# Altium schematic sheet Battery - Current_Probe_V0.2.SchDoc
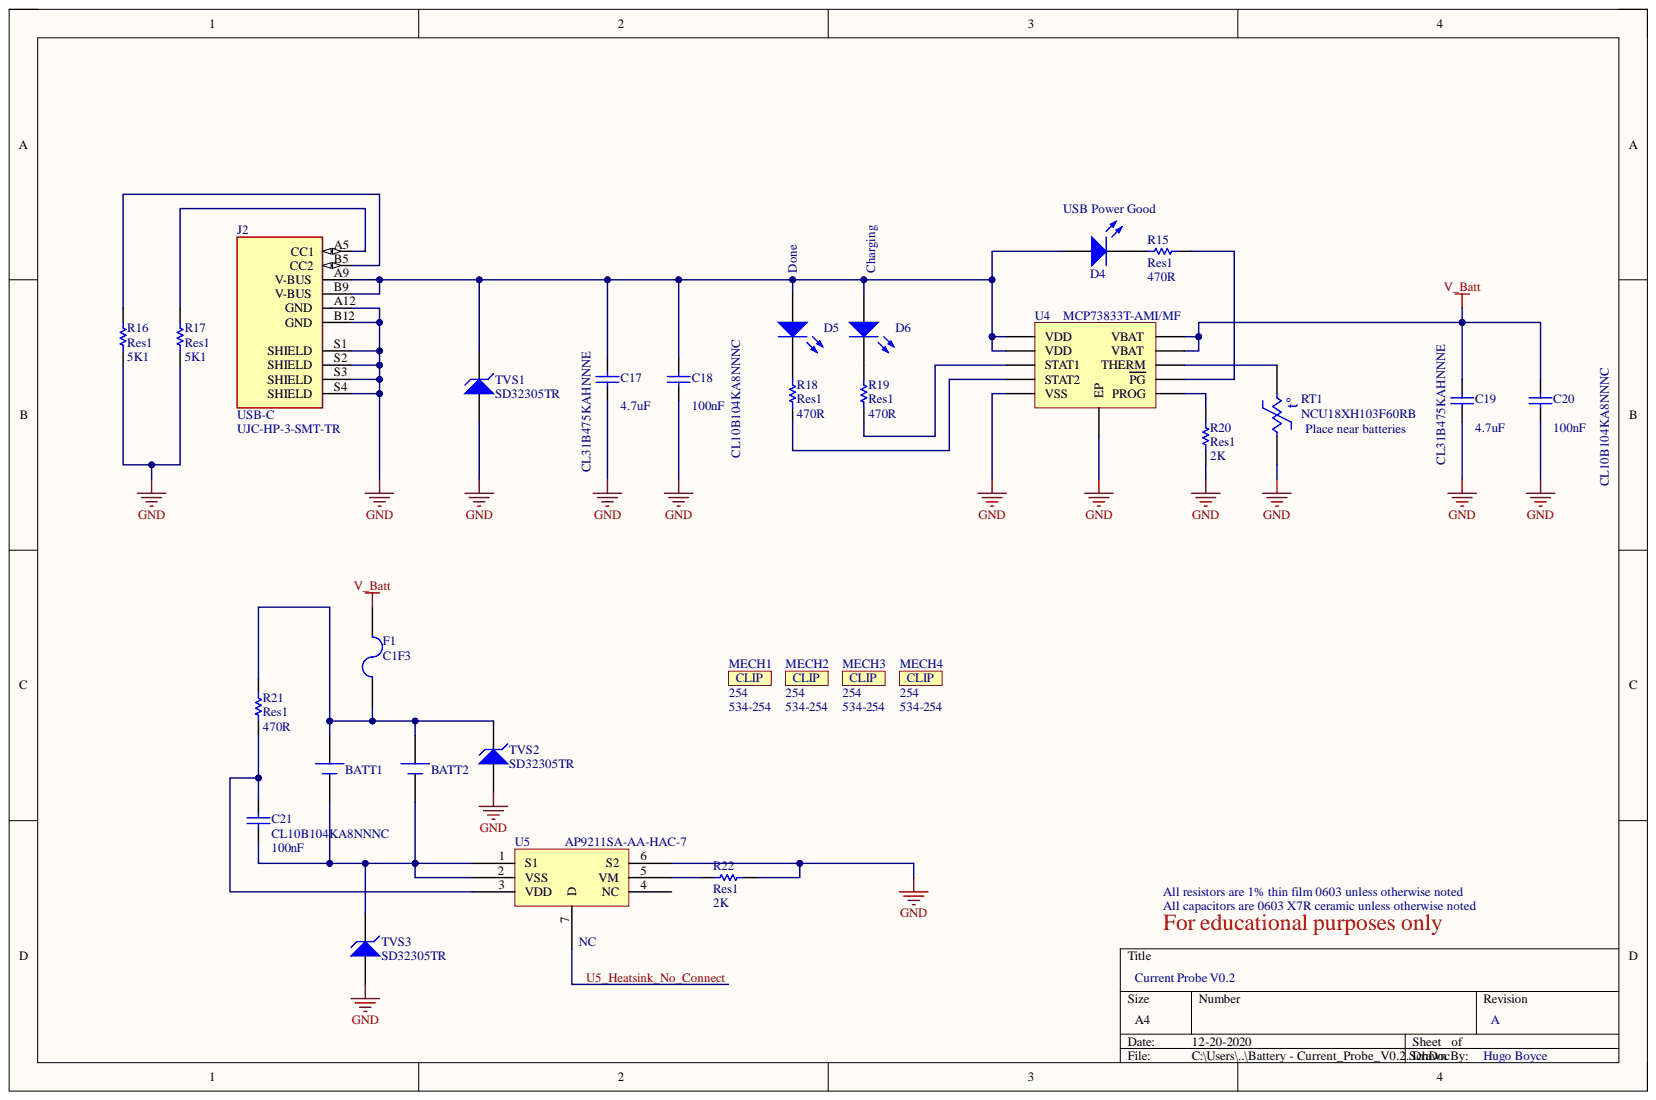
[diagram p0: Battery - Current_Probe_V0.2.SchDoc]

## Parts
BATT1  Li-ion_18650_Generic_Keystone_254_Clips  [Li-ion_18650_Generic_Keystone_254_Clips]  (value not drawn)
BATT2  i-ion_18650_Generic_Keystone_254_Clips  [Li-ion_18650_Generic_Keystone_254_Clips]  (value not drawn)
C17  CL31B475KAHNNNE  value 4.7uF  [CAPC3216N]
C18  CL10B104KA8NNNC  value 100nF  [CAPC1608N]
C19  CL31B475KAHNNNE  value 4.7uF  [CAPC3216N]
C20  CL10B104KA8NNNC  value 100nF  [CAPC1608N]
C21  CL10B104KA8NNNC  value 100nF  [CAPC1608N]
D4  150120RS75000  [150120RS75000]  (value not drawn)
D5  150120RS75000  [150120RS75000]  (value not drawn)
D6  150120RS75000  [150120RS75000]  (value not drawn)
F1  C1F3  [BEL_FUSE_C1F]
J2  USB-C  [UJC-HP-3-SMT-TR]
MECH1  254  Supplier Part Number 1: 534-254
MECH2  254  Supplier Part Number 1: 534-254
MECH3  254  Supplier Part Number 1: 534-254
MECH4  254  Supplier Part Number 1: 534-254
R15  Res1  value 470R  [RESC1608N]
R16  Res1  value 5K1  [RESC1608N]
R17  Res1  value 5K1  [RESC1608N]
R18  Res1  value 470R  [RESC1608N]
R19  Res1  value 470R  [RESC1608N]
R20  Res1  value 2K  [RESC1608N]
R21  Res1  value 470R  [RESC1608N]
R22  Res1  value 2K  [RESC1608N]
RT1  NCU18XH103F60RB  [RESC1608N]
TVS1  SD32305TR  [SODFL260X100-2N]
TVS2  SD32305TR  [SODFL260X100-2N]
TVS3  SD32305TR  [SODFL260X100-2N]
U4  MCP73833T-AMI/MF  [MCP73833_DFN10]
U5  AP9211SA-AA-HAC-7  [U-DFM2030-6_AP9211]

## Nets
GND: C17.1 C18.1 C19.1 C20.1 J2.A12(GND) J2.B12(GND) J2.S1(SHIELD) J2.S2(SHIELD) J2.S3(SHIELD) J2.S4(SHIELD) R16.2 R17.2 R20.1 R22.1 RT1.2 TVS1.A TVS2.A TVS3.A U4.5(VSS) U4.11(EP) U5.6(S2)
V_Batt: C19.2 C20.2 F1.2 U4.9(VBAT) U4.10(VBAT)
U5_Heatsink_No_Connect: U5.7(D)
NetBATT1_1: BATT1.1 BATT2.1 F1.1 R21.2 TVS2.K
NetBATT1_2: BATT1.2 BATT2.2 C21.1 TVS3.K U5.1(S1) U5.2(VSS)
NetC17_2: C17.2 C18.2 D4.2 D5.2 D6.2 J2.A9(V-BUS) J2.B9(V-BUS) TVS1.K U4.1(VDD) U4.2(VDD)
NetC21_2: C21.2 R21.1 U5.3(VDD)
NetD4_1: D4.1 R15.2
NetD5_1: D5.1 R18.2
NetD6_1: D6.1 R19.2
NetJ2_A5: J2.A5(CC1) R17.1
NetJ2_B5: J2.B5(CC2) R16.1
NetR15_1: R15.1 U4.7(PG)
NetR18_1: R18.1 U4.4(STAT2)
NetR19_1: R19.1 U4.3(STAT1)
NetR20_2: R20.2 U4.6(PROG)
NetR22_2: R22.2 U5.5(VM)
NetRT1_1: RT1.1 U4.8(THERM)

Not connected: U5 4(NC)

## Library data of the parts
BATT1 BATT2 = Li-ion_18650_Generic_Keystone_254_Clips: Generic 18650 battery with Keystone 254 clips; Component Kind: Standard; Library Name: Current_Probe_Library.SchLib
C17 C19 = CL31B475KAHNNNE: Cap Ceramic 4.7uF 25V X7R 10% SMD 1206 125C Embossed T/R; Manufacturer: Samsung; Capacitance: 4700000 pF; Case Size/Dimension: 1206; Component Kind: Standard; ComponentLink1Description: http://www.samsungsem.com/kr/support/product-search/mlcc/CL31B475KAHNNNE.jsp?src-supplier=Digi-Key; ComponentLink1URL: http://www.samsungsem.com/kr/support/product-search/mlcc/CL31B475KAHNNNE.jsp?src-supplier=Digi-Key; ComponentLink2Description: https://www.distrelec.biz/Web/Downloads/_e/ng/MLCC_CatalogDownload2013_ENG.pdf?mime=application%2Fpdf&src-supplier=Distrelec; ComponentLink2URL: https://www.distrelec.biz/Web/Downloads/_e/ng/MLCC_CatalogDownload2013_ENG.pdf?mime=application%2Fpdf&src-supplier=Distrelec; ComponentLink3Description: http://www1.futureelectronics.com/doc/SAMSUNG/CL10C1R5BB8NNNC.pdf?src-supplier=Future+Electronics; ComponentLink3URL: http://www1.futureelectronics.com/doc/SAMSUNG/CL10C1R5BB8NNNC.pdf?src-supplier=Future+Electronics; ComponentLink4Description: http://www.produktinfo.conrad.com/datenblaetter/450000-474999/452772-da-01-en-VS_KONDENSATOR_1206.pdf?src-supplier=Conrad; ComponentLink4URL: http://www.produktinfo.conrad.com/datenblaetter/450000-474999/452772-da-01-en-VS_KONDENSATOR_1206.pdf?src-supplier=Conrad; ComponentLink5Description: http://www.produktinfo.conrad.com/datenblaetter/450000-474999/452785-da-01-en-VS_KONDENSATOR_1206.pdf?src-supplier=Conrad; ComponentLink5URL: http://www.produktinfo.conrad.com/datenblaetter/450000-474999/452785-da-01-en-VS_KONDENSATOR_1206.pdf?src-supplier=Conrad; Dielectric: X7R; Manufacturer Part Number: CL31B475KAHNNNE; Pricing 1: 1=0.22, 10=0.152, 50=0.0912, 100=0.077, 500=0.0527, 1000=0.0466 (USD); Rated Voltage: 25 V; Stock 1: 137774; Supplier 1: Digi-Key; Supplier Part Number 1: 1276-1055-1-ND; Tolerance (%): ±10 %; Library Name: Current_Probe_Library.SchLib
C18 C20 C21 = CL10B104KA8NNNC: CAP CER 0.1UF 25V X7R 0603; Manufacturer: Samsung; Capacitance: 100000 pF; Tolerance (%): ±10 %; Case Size/Dimension: 0603; Component Kind: Standard; ComponentLink1Description: http://www.samsungsem.com/kr/support/product-search/mlcc/__icsFiles/afieldfile/2018/08/29/Data%20sheet_CL10B104KA8NNNC.pdf?src-supplier=Digi-Key; ComponentLink1URL: http://www.samsungsem.com/kr/support/product-search/mlcc/__icsFiles/afieldfile/2018/08/29/Data%20sheet_CL10B104KA8NNNC.pdf?src-supplier=Digi-Key; ComponentLink2Description: https://www.verical.com/server-webapp/html/getDatasheet?partID=354050&src-supplier=Verical; ComponentLink2URL: https://www.verical.com/server-webapp/html/getDatasheet?partID=354050&src-supplier=Verical; Dielectric: X7R; Manufacturer Part Number: CL10B104KA8NNNC; Pricing 1: 1=0.1, 10=0.029, 50=0.016, 100=0.013, 500=0.0093, 1000=0.0073 (USD); Rated Voltage: 25 V; Stock 1: 4133737; Supplier 1: Digi-Key; Supplier Part Number 1: 1276-1006-1-ND; Library Name: Current_Probe_Library.SchLib
D4-D6 = 150120RS75000: LED RED CLEAR 1206 SMD; Manufacturer: Wurth Electronics Inc.; Current - Test: 20mA; Lens Color: Colorless; Lens Style/Size: Rectangle with Flat Top, 2.00mm x 1.60mm; Millicandela Rating: 150mcd; Viewing Angle: 140°; Voltage - Forward (Vf) (Typ): 2V; Wavelength - Dominant: 625nm; Wavelength - Peak: 630nm; Category: Optoelectronics; Color: Red; Component Kind: Standard; ComponentLink1Description: http://katalog.we-online.de/led/datasheet/150120RS75000.pdf; ComponentLink1URL: http://katalog.we-online.de/led/datasheet/150120RS75000.pdf; Features: -; Height (Max): 0.69mm; Lens Transparency: Clear; Manufacturer Part Number: 150120RS75000; Mounting Type: Surface Mount; Package / Case: 1206 (3216 Metric); Packaging: Cut Tape (CT); Part Status: Active; Pricing 1: 1=0.27, 50=0.2582, 100=0.2211, 500=0.18952 (CAD); RoHS: RoHS Compliant; Size / Dimension: 3.20mm L x 1.60mm W; Stock 1: 14076; Supplier 1: Digi-Key; Supplier Device Package: 1206; Supplier Part Number 1: 732-4991-1-ND; Library Name: Current_Probe_Library.SchLib
F1 = C1F3: FUSE 3.0A 125VAC FAST 1206; Manufacturer: Bel TRP; Approvals: cURus; Breaking Capacity @ Rated Voltage: 100A AC, 50A DC; Categories: Circuit Protection; Current Rating: 3A; DC Cold Resistance: 0.039 Ohms; Fuse Type: Board Mount (Cartridge Style Excluded); Melting I²t: 0.19; Voltage Rating - AC: 125V; Voltage Rating - DC: 63V; Color: -; Component Kind: Standard; Manufacturer Part Number: C1F3; Mounting Type: Surface Mount; Operating Temperature: -55°C ~ 125°C; Package / Case: 1206 (3216 Metric); Packaging: Cut Tape (CT); Part Status: Active; Pricing 1: 1=0.29, 5=0.278, 10=0.265, 50=0.2366, 100=0.2203, 250=0.1958, 500=0.1632, 1000=0.155 (USD); Response Time: Fast; Series: C1F; Size / Dimension: 0.126 L x 0.062 W (3.20mm x 1.58mm); Stock 1: 4601; Supplier 1: Digi-Key; Supplier Part Number 1: 507-1883-1-ND; Library Name: Current_Probe_Library.SchLib
J2 = UJC-HP-3-SMT-TR: USB-C Female NO DATA; Manufacturer: CUI Devices; Component Kind: Standard; Manufacturer Part Number: UJC-HP-3-SMT-TR; Pricing 1: 1=0.85, 10=0.678, 100=0.59, 500=0.53, 1000=0.472, 2000=0.412 (USD); Stock 1: 0; Supplier 1: Mouser; Supplier Part Number 1: 490-UJC-HP-3-SMT-TR; Library Name: Current_Probe_Library.SchLib
MECH1-MECH4 = 254: Battery Contact Clip 18650 SMD; Manufacturer: Keystone Electronics; Component Kind: Standard; Manufacturer Part Number: 254; Pricing 1: 1=0.45, 10=0.413, 25=0.367, 100=0.352, 250=0.294, 500=0.284 (USD); Stock 1: 194; Supplier 1: Mouser; Library Name: Current_Probe_Library.SchLib
R15-R22 = Res1: Resistor; Component Kind: Standard; LatestRevisionDate: 17-Jul-2002; LatestRevisionNote: Re-released for DXP Platform.; Library Name: Miscellaneous Devices.SchLib; PackageDescription: Axial Device, Thru-Hole; 2 Leads; 0.3 in Pin Spacing; PackageReference: AXIAL-0.3; Published: 8-Jun-2000; Signal Integrity: Res; Simulation: RESISTOR; Publisher: Altium Limited
RT1 = NCU18XH103F60RB: THERMISTOR NTC 10KOHM 3380K 0603; Manufacturer: Murata; B25/85: 3434K; B25/100: 3455K; B Value Tolerance: ±1%; Categories: Sensors, Transducers; Power - Max: 100mW; Resistance in Ohms @ 25°C: 10k; B0/50: -; B25/50: 3380K; B25/75: -; Component Kind: Standard; ComponentLink1Description: http://www.farnell.com/datasheets/2095755.pdf?src-supplier=Element14; ComponentLink1URL: http://www.farnell.com/datasheets/2095755.pdf?src-supplier=Element14; ComponentLink2Description: https://www.murata.com/en-us/products/productdata/8796836233246/L0770E.pdf?src-supplier=Digi-Key; ComponentLink2URL: https://www.murata.com/en-us/products/productdata/8796836233246/L0770E.pdf?src-supplier=Digi-Key; ComponentLink3Description: http://www.farnell.com/datasheets/2095755.pdf?src-supplier=Newark; ComponentLink3URL: http://www.farnell.com/datasheets/2095755.pdf?src-supplier=Newark; ComponentLink4Description: http://www.farnell.com/datasheets/2095755.pdf?src-supplier=Farnell; ComponentLink4URL: http://www.farnell.com/datasheets/2095755.pdf?src-supplier=Farnell; Length - Lead Wire: -; Manufacturer Part Number: NCU18XH103F60RB; Mounting Type: Surface Mount; Operating Temperature: -40°C ~ 125°C; Package / Case: 0603 (1608 Metric); Packaging: Digi-Reel®; Part Status: Active; Pricing 1: 1=0.12, 5=0.114, 10=0.101, 25=0.0928, 50=0.0742, 100=0.0636, 500=0.0557, 1000=0.0477 (USD); Resistance Tolerance: ±1%; Series: NCU; Stock 1: 40572; Supplier 1: Digi-Key; Supplier Part Number 1: 490-16279-1-ND; Library Name: Current_Probe_Library.SchLib
TVS1-TVS3 = SD32305TR: TVS DIODE 5V 9.8V SOD323; Manufacturer: SMC; Capacitance @ Frequency: 600pF @ 1MHz; Categories: Circuit Protection; Current - Peak Pulse (10/1000µs): 1A (8/20µs); Power - Peak Pulse: 300W; Power Line Protection: No; Unidirectional Channels: 1; Voltage - Breakdown (Min): 6V; Voltage - Reverse Standoff (Typ): 5V (Max); Applications: General Purpose; Component Kind: Standard; ComponentLink1Description: http://www.smc-diodes.com/propdf/SD32303%20THRU%20SD32324%20N0428%20REV.B.pdf?src-supplier=Digi-Key; ComponentLink1URL: http://www.smc-diodes.com/propdf/SD32303%20THRU%20SD32324%20N0428%20REV.B.pdf?src-supplier=Digi-Key; Manufacturer Part Number: SD32305TR; Mounting Type: Surface Mount; Operating Temperature: -55°C ~ 125°C (TJ); Package / Case: SC-76, SOD-323; Packaging: Digi-Reel®; Part Status: Active; Pricing 1: 1=0.22, 10=0.179, 25=0.1496, 100=0.0732, 500=0.061, 1000=0.0424 (USD); Series: -; Stock 1: 23873; Supplier 1: Digi-Key; Supplier Device Package: SOD-323; Supplier Part Number 1: 1655-1528-1-ND; Type: Zener; Voltage - Clamping (Max) @ Ipp: 9.8V; Library Name: Current_Probe_Library.SchLib
U4 = MCP73833T-AMI/MF: IC LI-ION/LI-POLY CTRLR 10DFN; Manufacturer: Microchip; Operating Supply Voltage (Max): 6V; Operating Supply Voltage (Min): 3.75V; Output Current: 1.2A; Output Voltage: 4.2/4.35/4.4/4.5V; Battery Type: Li-Ion/Li-Pol; Component Kind: Standard; ComponentLink1Description: http://ww1.microchip.com/downloads/jp/DeviceDoc/jp027983.pdf?src-supplier=Avnet; ComponentLink1URL: http://ww1.microchip.com/downloads/jp/DeviceDoc/jp027983.pdf?src-supplier=Avnet; ComponentLink2Description: http://ww1.microchip.com/downloads/jp/DeviceDoc/jp027983.pdf?src-supplier=Avnet+Europe; ComponentLink2URL: http://ww1.microchip.com/downloads/jp/DeviceDoc/jp027983.pdf?src-supplier=Avnet+Europe; ComponentLink3Description: http://www.microchip.com/mymicrochip/filehandler.aspx?ddocname=en026002&src-supplier=Digi-Key; ComponentLink3URL: http://www.microchip.com/mymicrochip/filehandler.aspx?ddocname=en026002&src-supplier=Digi-Key; ComponentLink4Description: https://www.verical.com/server-webapp/html/getDatasheet?partID=758826&src-supplier=Verical; ComponentLink4URL: https://www.verical.com/server-webapp/html/getDatasheet?partID=758826&src-supplier=Verical; Manufacturer Part Number: MCP73833T-AMI/MF; Mounting: Surface Mount; Operating Temp Range: -40C to 85C; Operating Temperature Classification: Industrial; Package Type: DFN EP; Packaging: Tape and Reel; Pin Count: 10; Pricing 1: 1=0.85, 25=0.71, 100=0.65 (USD); Stock 1: 0; Supplier 1: Digi-Key; Supplier Part Number 1: MCP73833T-AMI/MFCT-ND; Type: Linear Charge Management Controller; Library Name: Current_Probe_Library.SchLib
U5 = AP9211SA-AA-HAC-7: IC BATT PROTECTION LI-ION 6UDFN; Manufacturer: Diodes; Battery Chemistry: Lithium-Ion; Drawing Number: 31; U-DFN2030-6 Type C; ; 6; Fault Protection: Over Current, Over Voltage; Function: Battery Protection; Number of Cells: 1; Category: Integrated Circuits (ICs); Component Kind: Standard; ComponentLink1Description: https://www.diodes.com/assets/Datasheets/AP9211.pdf?src-supplier=Digi-Key; ComponentLink1URL: https://www.diodes.com/assets/Datasheets/AP9211.pdf?src-supplier=Digi-Key; Family: PMIC - Battery Management; Interface: -; Manufacturer Part Number: AP9211SA-AA-HAC-7; Operating Temperature: -40°C ~ 85°C (TA); Package / Case: 6-UDFN Exposed Pad; Packaging: Tape & Reel (TR); Pricing 1: 1=0.66, 10=0.58, 25=0.5448, 100=0.413, 250=0.3515, 500=0.3339, 1000=0.2548 (USD); Series: -; Stock 1: 9632; Supplier 1: Digi-Key; Supplier Device Package: U-DFN2030-6; Supplier Part Number 1: AP9211SA-AA-HAC-7DICT-ND; Library Name: Current_Probe_Library.SchLib
